# Altium schematic sheet [Saberstick] AtMega328P.SchDoc
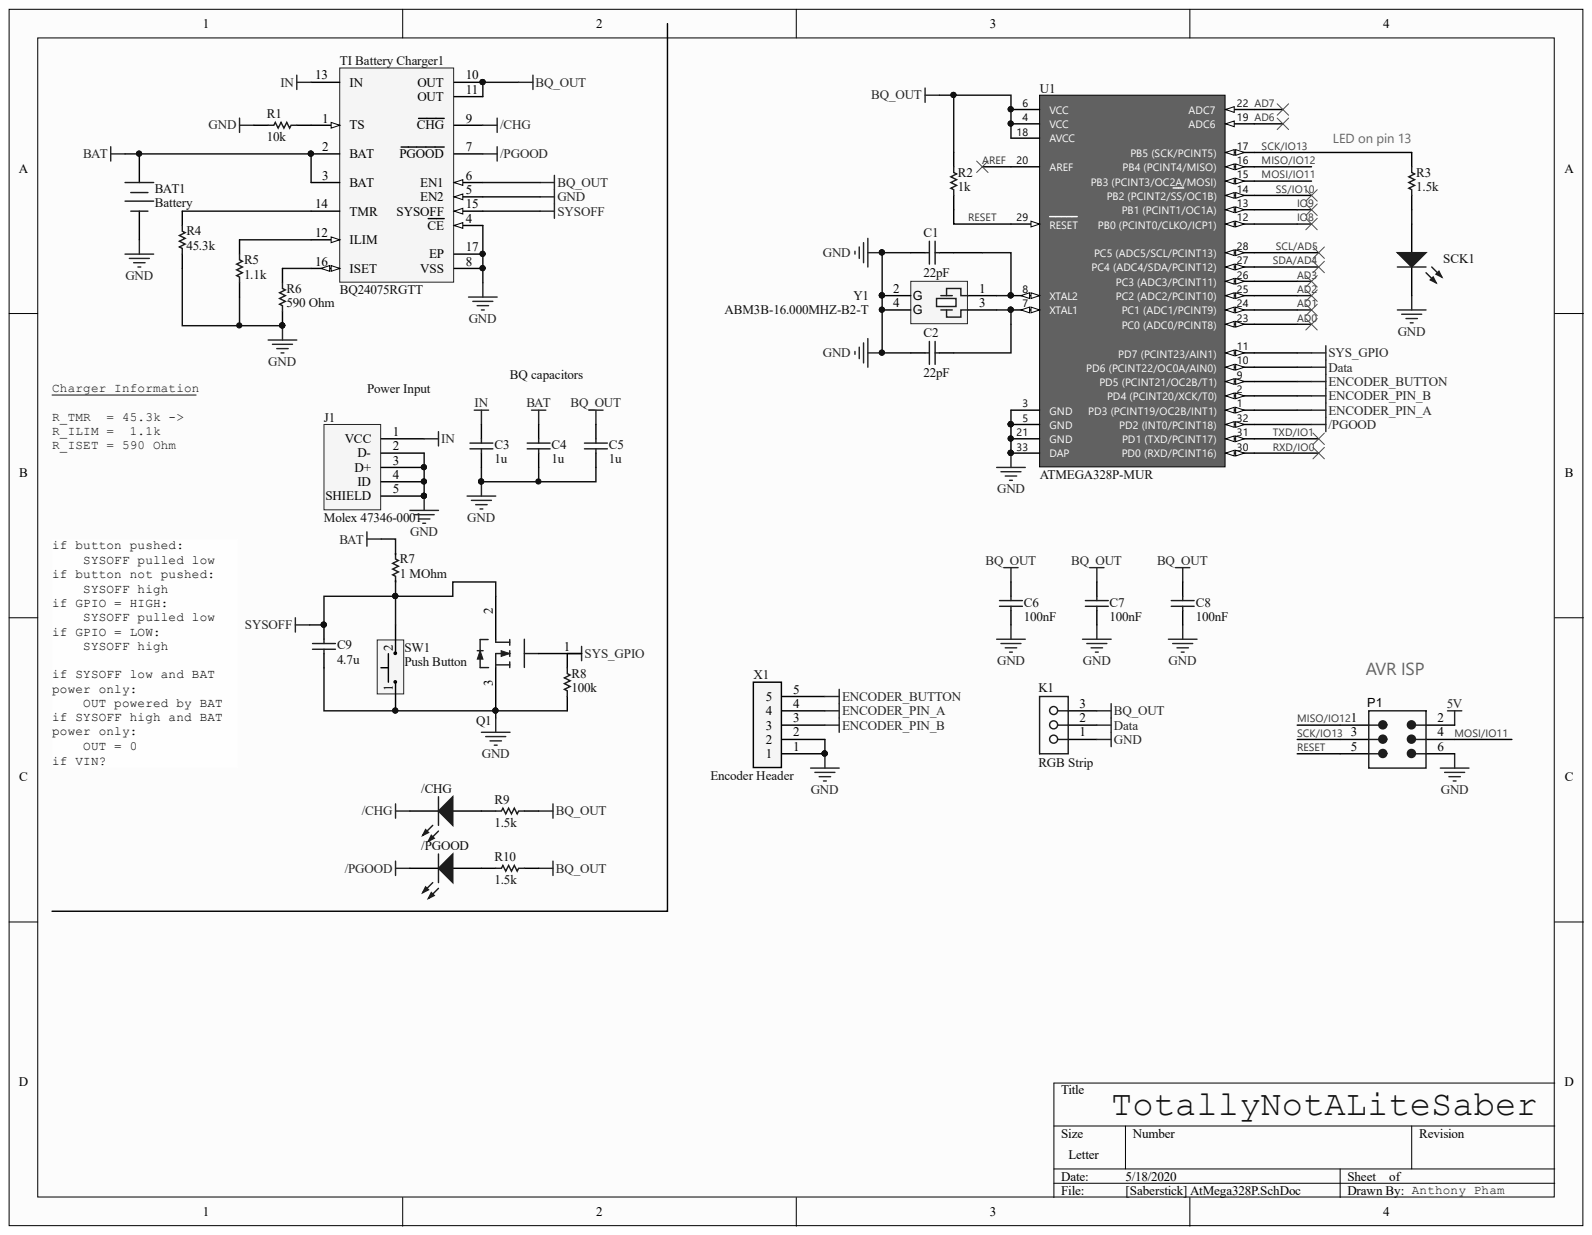
[diagram p0: [Saberstick] AtMega328P.SchDoc]

## Parts
/CHG  CMP-12518893-2  [Type 3mm]  (value not drawn)
/PGOOD  CMP-12518893-2  [Type 3mm]  (value not drawn)
BAT1  Battery  [PCB-nmv0vzhkfwsbxqx6kc1g-1]
C1  22pF  [C0805]
C2  22pF  [C0805]
C3  1u  [CAPC1608X90X35LL15T15]
C4  1u  [CAPC1608X90X35LL15T15]
C5  1u  [CAPC1608X90X35LL15T15]
C6  100nF  [PCB-2cfusdo6sp8ecp4m660c-1]
C7  100nF  [PCB-2cfusdo6sp8ecp4m660c-1]
C8  100nF  [PCB-2cfusdo6sp8ecp4m660c-1]
C9  4.7u  [CAPC2012X11N]
J1  Molex 47346-0001  [PCB-lw6upt0guqv556jll6nj-1]
K1  RGB Strip  [HDR-3RA-M]
P1  (no value)  [PCB-195qa83xwzmdfln5hnix-1]
Q1  N-Channel MOSFET  [ONSC-TO-220-3-221A-09]  (value not drawn)
R1  10k  [PCB-lfoq2zshv8871jnijg7w-1]
R2  1k  [PCB-bxx9w8jzwpp640jjc58j-1]
R3  1.5k  [RESC2013X60X30NL20T20]
R4  45.3k  [PCB-15394kepv9f7eff3yv9i-1]
R5  1.1k  [RESC2013X70X40LL20T20]
R6  590 Ohm  [RESC2013X60X30LL20T20]
R7  1 MOhm  [RESC2013X70X40NL20T20]
R8  100k  [RESC2013X60X35LL10T20]
R9  1.5k  [RESC2013X60X30NL20T20]
R10  1.5k  [RESC2013X60X30NL20T20]
SCK1  CMP-12518893-2  [Type 3mm]  (value not drawn)
SW1  Push Button  [PCB-ig79pzg5mdpht1qqvy53-1]
TI_Battery_Charger1  BQ24075RGTT  [RGT16-1700X1700TP]
U1  ATMEGA328P-MUR  [PCB-1210586-2]
X1  Encoder Header  [PCB-y3ve9b8dylm0s3m8lnid-1]
Y1  ABM3B-16.000MHZ-B2-T  [ABCN-ABM3B]

## Nets
5V: P1.2
/CHG: /CHG.1 TI_Battery_Charger1.9(CHG)
/PGOOD: /PGOOD.1 TI_Battery_Charger1.7(PGOOD) U1.32
BAT: BAT1.1 C4.2 R7.2 TI_Battery_Charger1.2(BAT) TI_Battery_Charger1.3(BAT)
BQ_OUT: C5.2 C6.2 C7.2 C8.2 K1.3 R2.2 R9.2 R10.2 TI_Battery_Charger1.6(EN1) TI_Battery_Charger1.10(OUT) TI_Battery_Charger1.11(OUT) U1.4(VCC) U1.6(VCC) U1.18(AVCC)
DATA: K1.2 U1.10(PD6_(PCINT22/OC0A/AIN0))
ENCODER_BUTTON: U1.9(PD5_(PCINT21/OC2B/T1)) X1.5
ENCODER_PIN_A: U1.1 X1.4
ENCODER_PIN_B: U1.2(PD4_(PCINT20/XCK/T0)) X1.3
GND: BAT1.2 C1.1 C2.1 C3.1 C4.1 C5.1 C6.1 C7.1 C8.1 C9.1 J1.2(D-) J1.3(D+) J1.4(ID) J1.5(SHIELD) K1.1 P1.6 Q1.3 R1.1 R4.1 R5.1 R6.1 R8.1 SCK1.1 SW1.1 TI_Battery_Charger1.4(CE) TI_Battery_Charger1.5(EN2) TI_Battery_Charger1.8(VSS) TI_Battery_Charger1.17(EP) U1.3(GND) U1.5(GND) U1.21(GND) U1.33(DAP) X1.1 X1.2 Y1.2(G) Y1.4(G)
IN: C3.2 J1.1(VCC) TI_Battery_Charger1.13(IN)
SYS_GPIO: R8.2 U1.11(PD7_(PCINT23/AIN1))
SYSOFF: C9.2 R7.1 SW1.2 TI_Battery_Charger1.15(SYSOFF)
AD0: U1.23(PC0_(ADC0/PCINT8))
AD1: U1.24
AD2: U1.25
AD3: U1.26(PC3_(ADC3/PCINT11))
AD6: U1.19(ADC6)
AD7: U1.22(ADC7)
AREF: U1.20(AREF)
IO8: U1.12
IO9: U1.13(PB1_(PCINT1/OC1A))
MISO/IO12: P1.1 U1.16
MOSI/IO11: P1.4 U1.15(PB3_(PCINT3/OC2A/MOSI))
RESET: P1.5 R2.1 U1.29(RESET)
RXD/IO0: U1.30
SCK/IO13: P1.3 R3.2 U1.17(PB5_(SCK/PCINT5))
SCL/AD5: U1.28(PC5_(ADC5/SCL/PCINT13))
SDA/AD4: U1.27(PC4_(ADC4/SDA/PCINT12))
SS/IO10: U1.14(PB2_(PCINT2/SS/OC1B))
TXD/IO1: U1.31
Net/CHG_2: /CHG.2 R9.1
Net/PGOOD_2: /PGOOD.2 R10.1
NetC1_2: C1.2 U1.8(XTAL2) Y1.1
NetC2_2: C2.2 U1.7(XTAL1) Y1.3
NetR1_2: R1.2 TI_Battery_Charger1.1(TS)
NetR3_1: R3.1 SCK1.2
NetR4_2: R4.2 TI_Battery_Charger1.14(TMR)
NetR5_2: R5.2 TI_Battery_Charger1.12(ILIM)
NetR6_2: R6.2 TI_Battery_Charger1.16(ISET)

Not connected: Q1 1 2

## Library data of the parts
/CHG /PGOOD SCK1 = CMP-12518893-2: THT LED round mono-color waterclear, WL-TMRW, Yellow; Manufacturer Part Number: 151033YS03000; Forward Voltage: 2.0 V; Viewing Angle: 30 °; Component Kind: Standard; Library Name: CommunityVault; OctopartID: fd51359ead042296; Octopart Url: https://octopart.com/151033ys03000-w%C3%BCrth+elektronik-29717811; REACH SVHC Compliance: No SVHC; RoHS: Compliant
BAT1 = 1043P: Pcb Holder Thm 18650 Polarized; Component Kind: Standard; Library Name: Octopart library; Lifecycle Status: Not Listed by Manufacturer; Octopart URL: http://octopart.com/1043p-keystone-31888867
C1 C2 = CC0805JRNPO9BN220: 0805 22 pF 50 V ±5 % Tolerance NP0 SMT Multilayer Ceramic Capacitor; Capacitance: 2.2e-11 F; Capacitance Tolerance: ±5%; Dielectric Characteristic: C0G/NP0; Lead-Free Status: Lead Free; Voltage Rating (DC): 50.0 V; Case/Package: 0805; Component Kind: Standard; Dielectric Material: Ceramic; Halogen Free Status: Halogen Free; Library Name: Octopart library; Manufacturer Part Number: CC0805JRNPO9BN220; Mounting Style: Surface Mount; Number of Pins: 2; OctopartID: 05bece31d998da79; Octopart Url: http://octopart.com/cc0805jrnpo9bn220-yageo-42334528; Packaging: Cut Tape (CT); REACH SVHC Compliance: No SVHC; RoHS: Compliant; Seller Landing  Url: https://octopart-clicks.com/click/track?country=US&ak=8157f166&sig=0a0fff6&sid=5489&ppid=42334528&vpid=123646948&ct=offers
C3-C5 = 06036D105KAT2A: 0603 1 uF 6.3 V ±10 % Tolerance X5R Surface Mount Multilayer Ceramic Capacitor; Manufacturer: AVX; Capacitance Tolerance: ±10%; Component Kind: Standard; Library Name: Octopart library; Packaging: Tape & Reel (TR), Reel, Cut Tape (CT); Size-Height: 860 µm
C6-C8 = 08051C104K4T2A: CAP CER 0.1UF 100V 10% X7R 0805; Capacitance: 1e-07 F; Capacitance Tolerance: ±10%; Component Kind: Standard; Dielectric Characteristic: X7R; Library Name: Octopart library; Manufacturer Part Number: 08051C104K4T2A; Mounting Style: Surface Mount; Number of Pins: 2; OctopartID: a55e6c3878157a99; REACH SVHC Compliance: No SVHC; RoHS: Compliant
C9 = GRM21BR61C475KA88L: 0805 4.7 uF 16 V ±10% Tolerance X5R SMT Multilayer Ceramic Capacitor; Capacitance: 4.7e-06 F; Case/Package (SI): 2012; Lead-Free Status: Lead Free; Voltage Rating (DC): 16.0 V; Capacitance Tolerance: ±10%; Case/Package: 0805, 2012; Component Kind: Standard; Dielectric Characteristic: X5R; Library Name: Octopart library; Lifecycle Status: Active; Manufacturer Part Number: GRM21BR61C475KA88L; Mounting Style: Surface Mount; OctopartID: 11a0d8a9359bce67; Octopart URL: http://octopart.com/grm21br61c475ka88l-murata-196957; Packaging: Cut Tape (CT), Tape & Reel (TR); REACH SVHC Compliance: No SVHC; RoHS: Compliant; Size-Height: 0.00125 m; Size-Length: 0.002 m; Size-Thickness: 0.00125 m; Size-Width: 0.00125 m
J1 = CMP-2328464-13: Micro-USB B Receptacle with Flange, Bottom Mount, Surface Mount, Lead-Free; Component Kind: Standard; Library Name: CommunityVault
K1 = 61300311021: Board-To-Board Connector, Right Angle, WR-PHD Series, Through Hole, Header, 3, 2.54 mm; Gender: Male; Number of Contacts: 3; Component Kind: Standard; Contact Material: Copper; Contact Plating: Gold; Library Name: Octopart library; Manufacturer Part Number: 61300311021; Mounting Style: Through Hole; OctopartID: 812e49d1f1a85ee9; Octopart URL: http://octopart.com/61300311021-w%C3%BCrth+elektronik-32855520; REACH SVHC Compliance: No SVHC; RoHS: Compliant
P1 = CMP-3ebff6404e5de178-1: TE Connectivity AMPMODU Series, 2.54mm Pitch 6 Way 2 Row Straight PCB Header, Solder Termination, 3A; Manufacturer Part Number: 61000621121; 3D Model: 826656-3.pdf; CiivaID: 664156; Connector Type: Header, Unshrouded, Breakaway; Gender: Male; Number of Contacts: 6; Number of Positions Loaded: All; Pitch: 0.100" (2.54mm); Category: Connectors, Interconnects; Ciiva URL: https://ciiva.com/part/826656-3-664156; Color: Green; Component Kind: Standard; Contact Finish: Gold; Contact Finish Thickness: 31.5µin (0.80µm); Contact Material: Copper; Contact Mating Length: 0.228" (5.80mm); Contact Plating: Gold; Contact Type: Male Pin; Family: Rectangular Connectors - Headers, Male Pins; Library Name: CommunityVault; Mounting Style: Surface Mount, Vertical; Mounting Type: Through Hole; Number of Positions: 6; Number of Rows: 2; OctopartID: 3ebff6404e5de178; Octopart URL: http://octopart.com/61000621121-w%C3%BCrth+elektronik-32855428; Packaging: Bulk; REACH SVHC Compliance: No SVHC; RoHS: Compliant; RoHS Information: 826656-3 Statement of Compliance; Row Spacing: 0.100" (2.54mm); Series: AMPMODU Mod II; Standard Package: 3,024; Termination: Solder
Q1 = CSD18532KCS: MOSFET N-CH 60V 100A TO220-3; Lead-Free Status: Contains Lead; Component Kind: Standard; Library Name: Octopart library; Lifecycle Status: Active; Manufacturer Part Number: CSD18532KCS; Number of Pins: 3; OctopartID: 2faee7f7ce7ff069; Octopart Url: https://octopart.com/csd18532kcs-texas+instruments-22267063; Polarity: N-Channel; RoHS: Non-Compliant
R1 = CMP-1854303-35: Res Thick Film 0805 10K Ohm 1% 0.125W(1/8W) +/-100ppm/C Epoxy Pad SMD T/R; Manufacturer Part Number: RC0805FR-0710KL; Case/Package (SI): 2012; Lead-Free Status: Lead Free; Resistance: 10.0 kΩ; Temperature Coefficient: -100 ppm/°C to 100 ppm/°C; Voltage Rating (DC): 150 V; Component Kind: Standard; Library Name: CommunityVault; Mounting Style: Surface Mount; Number of Pins: 2; Octopart URL: https://octopart.com/rc0805fr-0710kl-yageo-40301103; REACH SVHC Compliance: No SVHC; RoHS: Compliant
R2 = ERJ-P06F1001V: Res Thick Film 0805 1K Ohm 1% 0.25W ±100ppm/°C Molded SMD Punched Carrier T/R; Lead-Free Status: Lead Free; Temperature Coefficient: -100 ppm/°C to 100 ppm/°C; Case/Package: 2012, 0805; Component Kind: Standard; Library Name: Octopart library; Manufacturer Part Number: ERJ-P06F1001V; Mounting Style: Surface Mount; Number of Pins: 2; Packaging: Cut Tape (CT), Tape & Reel (TR); REACH SVHC Compliance: No SVHC; RoHS: Compliant
R3 R9 R10 = SG73P2ATTD1501F: Thick Film Resistors - SMD 0.25W 1.5Kohm 1% 200ppm / Learn More; Case/Package (SI): 2012; Resistance: 1500.0 Ω; Temperature Coefficient: 200.0 ppm/°C; Voltage Rating (DC): 150.0 V; Case/Package: 0805; Component Kind: Standard; Library Name: Octopart library; Manufacturer Part Number: SG73P2ATTD1501F; Number of Pins: 2; OctopartID: 4c1d8561534f93da; Octopart Url: https://octopart.com/sg73p2attd1501f-koa+speer-7748148; Power Rating: 0.25 W; RoHS: Compliant
R4 = CRCW080545K3FKEA: Res Thick Film 0805 45.3K Ohm 1% 0.125W(1/8W) ±100ppm/C Molded SMD Automotive Paper T/R; Lead-Free Status: Lead Free; Component Kind: Standard; Library Name: Octopart library; Manufacturer Part Number: CRCW080545K3FKEA; Mounting Style: Surface Mount; OctopartID: e76d2c3890db38aa; Octopart URL: http://octopart.com/crcw080545k3fkea-vishay+dale-39801552; REACH SVHC Compliance: No SVHC
R5 = ERJ-6ENF1101V: Thick Film Resistors - SMD 0805 1.1Kohms 1% Tol; Case/Package (SI): 2012; Lead-Free Status: Lead Free; Power Rating: 0.125 W; Resistance: 1100.0 Ω; Voltage Rating (DC): 150.0 V; Case/Package: 0805; Component Kind: Standard; Library Name: Octopart library; Lifecycle Status: Not Listed by Manufacturer; Manufacturer Part Number: ERJ-6ENF1101V; Mounting Style: Surface Mount; OctopartID: 3600fc47be10e9c7; Octopart Url: https://octopart.com/erj-6enf1101v-panasonic-55422326; Packaging: Cut Tape (CT); REACH SVHC Compliance: No SVHC; Resistance Tolerance: ±1%; RoHS: Compliant; Size-Height: 0.0006 m; Size-Length: 0.002 m; Size-Width: 0.00125 m
R6 = RK73H2ATTD5900F: Thick Film Resistors - SMD 1/8watts 590ohms 1%; Case/Package (SI): 2012; Lead-Free Status: Lead Free; Power Rating: 0.125 W; Resistance: 590.0 Ω; Temperature Coefficient: 100.0 ppm/°C; Voltage Rating (DC): 150.0 V; Case/Package: 0805; Component Kind: Standard; Library Name: Octopart library; Manufacturer Part Number: RK73H2ATTD5900F; OctopartID: ca3b0310fcad15fc; Octopart Url: https://octopart.com/rk73h2attd5900f-koa+speer-2167792; Resistance Tolerance: ±1%; RoHS: Compliant; Size-Length: 0.002 m; Size-Width: 0.00125 m
R7 = ERJ-6ENF1004V: Thick Film Resistors 0805 1Mohms 1% Tol; Case/Package (SI): 2012; Lead-Free Status: Lead Free; Power Rating: 0.125 W; Resistance: 1000000.0 Ω; Voltage Rating (DC): 150.0 V; Case/Package: 0805; Component Kind: Standard; Library Name: Octopart library; Lifecycle Status: Not Listed by Manufacturer; Manufacturer Part Number: ERJ-6ENF1004V; Mounting Style: Surface Mount; Number of Pins: 2; OctopartID: 6b86a1c12a42b441; Octopart Url: https://octopart.com/erj-6enf1004v-panasonic-55403682; Packaging: Cut Tape (CT); REACH SVHC Compliance: No SVHC; Resistance Tolerance: ±1%; RoHS: Compliant; Size-Height: 0.0006 m; Size-Length: 0.002 m; Size-Width: 0.00125 m
R8 = RC0805FR-07100KL: Res Thick Film 0805 100K Ohm 1% 0.125W(1/8W} 100ppm/Molded SMD Paper T/R; Component Kind: Standard; Library Name: Octopart library
SW1 = 1825910-6: Switch Tactile OFF (ON) SPST Round Button PC Pins 0.05A 24VDC 100000Cycles 1.57N Thru-Hole Loose; Component Kind: Standard; Library Name: Octopart library
TI_Battery_Charger1 = BQ24075RGTT: USB-Friendly Li-Ion Battery Charger and Power-Path Management IC, Vout 5.5 V, 4.3 V, -40 to 85 degC, 16-pin QFN (RGT), Green (RoHS & no Sb/Br); Input Voltage (DC): 6.4 V; Lead-Free Status: Lead Free; Component Kind: Standard; Library Name: Octopart library; Lifecycle Status: Active; Manufacturer Part Number: BQ24075RGTT; Mounting Style: Surface Mount; Number of Pins: 16; OctopartID: 9f37568443f840f0; Octopart Url: https://octopart.com/bq24075rgtt-texas+instruments-12188073; Packaging: Tape & Reel (TR); REACH SVHC Compliance: No SVHC; RoHS: Compliant
U1 = CMP-1210586-12: MCU AVR 32K FLASH 20MHZ 32QFN; Manufacturer: Microchip; Connectivity: I²C, SPI, UART/USART; Core Size: 8-Bit; Data Converters: A/D 8x10b; EEPROM Size: 128 x 8; Number of I /O: 24; Oscillator Type: Internal; Peripherals: Brown-out Detect/Reset, POR, PWM, WDT; Program Memory Size: 3.5KB (2K x 14); Voltage - Supply (Vcc/Vdd): 1.8 V ~ 5.5 V; Category: 8-bit Microcontrollers - MCU; CiivaID: 1210586; Ciiva URL: https://ciiva.com/part/atmega328p-mur-1210586; Component Kind: Standard; Core Processor: AVR; Library Name: CommunityVault; Manufacturer Part Number: ATMEGA328P-MUR; Operating Temperature: -40°C ~ +85°C; Package / Case: MLF-32; Packaging: Tube; Program Memory Type: FLASH; RAM Size: 128 x 8; Series: ATmega328P; Speed: 20MHz; Supplier 1: Mouser; Supplier Part Number 1: 556-ATMEGA328P-MUR
X1 = 61300511021: Board-To-Board Connector, Right Angle, WR-PHD Series, Through Hole, Header, 5, 2.54 mm; Gender: Male; Number of Contacts: 5; Component Kind: Standard; Contact Material: Copper; Contact Plating: Gold; Library Name: Octopart library; Manufacturer Part Number: 61300511021; Mounting Style: Through Hole; OctopartID: 2981e17e5902f646; Octopart URL: http://octopart.com/61300511021-w%C3%BCrth+elektronik-32855525; REACH SVHC Compliance: No SVHC; RoHS: Compliant
Y1 = CMP-780013-1: CRYSTAL 16.000MHZ 18PF SMD; Associated Product: 535-10138-ND- SOCKET 4PAD 5.0X3.2 XTAL OR OSC535-10137-ND- SOCKET 4PAD 5.0X3.2 XTAL OR OSC535-10136-ND- SOCKET 4PAD 5.0X3.2 XTAL OR OSC535-10135-ND- SOCKET 4PAD 5.0X3.2 XTAL OR OSC535-10134-ND- SOCKET 4PAD 5.0X3.2 XTAL OR OSC; Catalog Drawings: ABM3B Series TopABM3B Series SideABM3B Series FootprintABM3B Series Circuit; CiivaID: 780013; Dynamic Catalog: ABM3B Series; ESR (Equivalent Series Resistance): 50 Ohm; Frequency: 16MHz; Frequency Stability: ±50ppm; Frequency Tolerance: ±20ppm; Operating Mode: Fundamental; Other Names: 535-9122-6; Category: Crystals and Oscillators; Ciiva URL: https://ciiva.com/part/ABM3B-16.000MHZ-B2-T-780013; Component Kind: Standard; Family: Crystals; Height: 0.043" (1.10mm); Library Name: CommunityVault; Load Capacitance: 18pF; Manufacturer Part Number: ABM3B-16.000MHZ-B2-T; Mounting Type: Surface Mount; Operating Temperature: -20°C ~ 70°C; Package / Case: 4-SMD, No Lead (DFN, LCC); Packaging: Digi-Reel®; Series: ABM3B; Size / Dimension: 0.197" L x 0.126" W (5.00mm x 3.20mm); Standard Package: 1; Type: MHz Crystal
